# Altium schematic sheet [8]Sensing_SDC.SchDoc([8]Sensing_SDC)
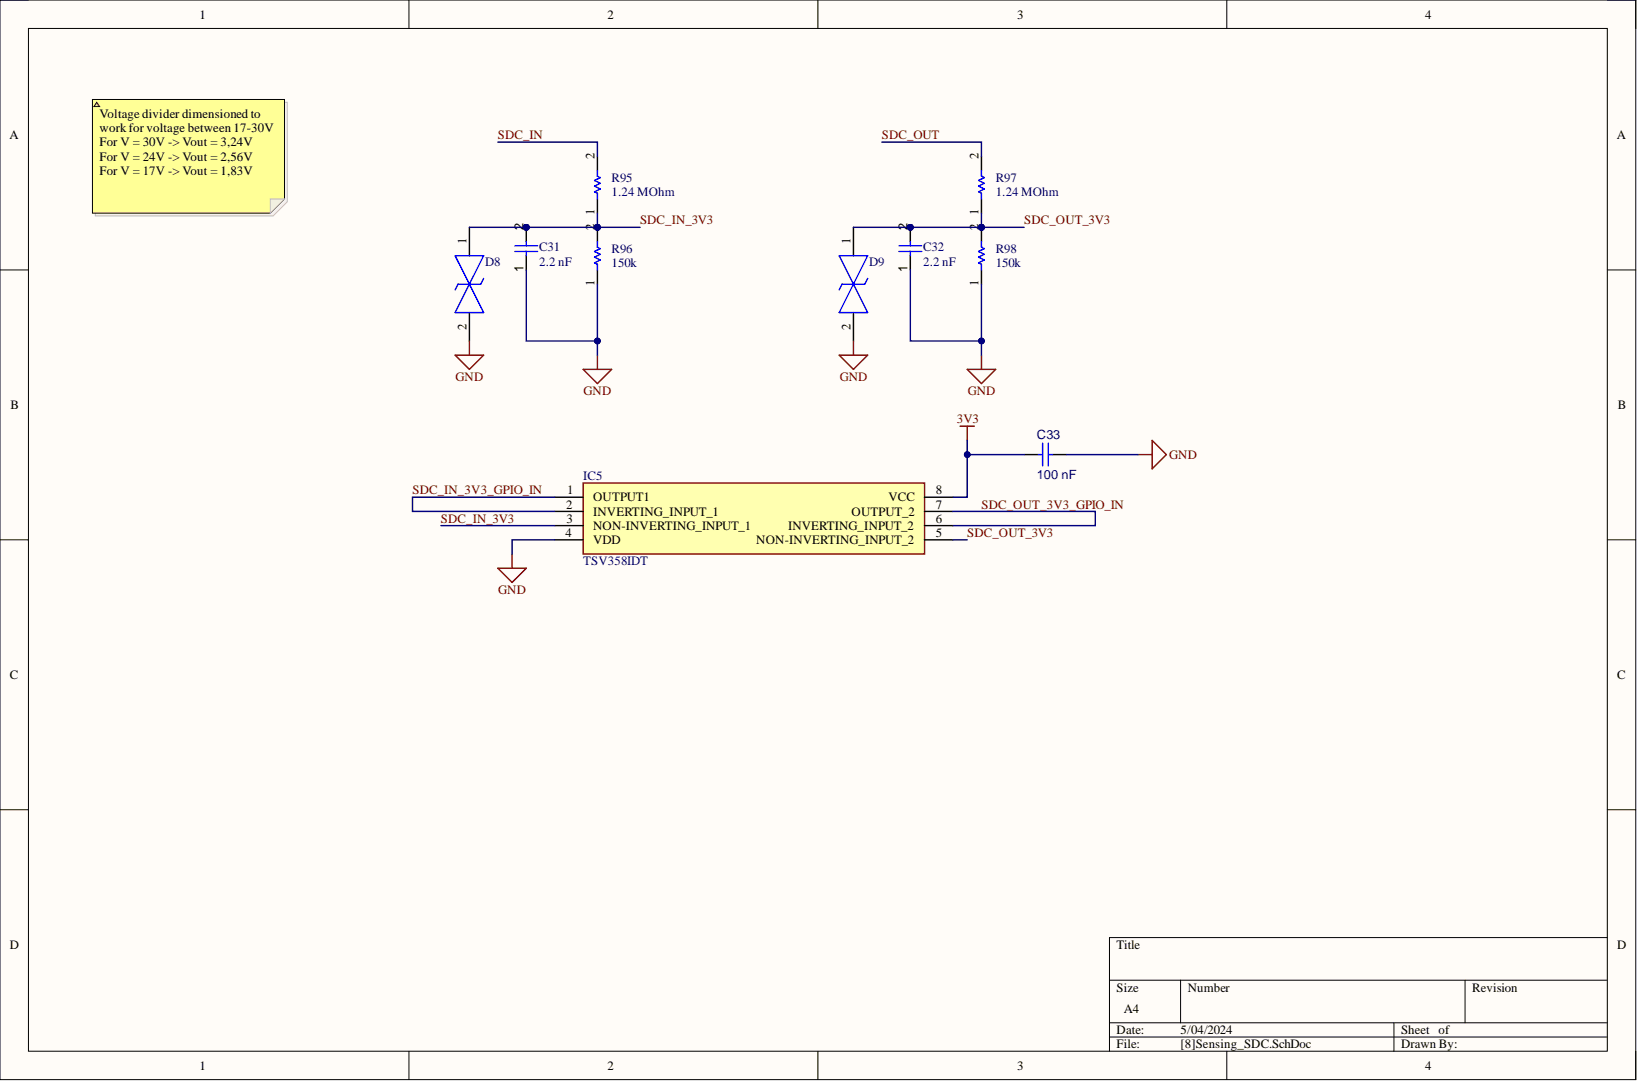
[diagram p0: [8]Sensing_SDC.SchDoc]

## Parts
C31  2.2 nF
C32  2.2 nF
C33  100 nF
D8  SMAJ30CA-TR  (value not drawn)
D9  SMAJ30CA-TR  (value not drawn)
IC5  TSV358IDT
R95  1.24 MOhm
R96  150k
R97  1.24 MOhm
R98  150k

## Nets
3V3: C33.2 IC5.8(VCC)
GND: C31.1 C32.1 C33.1 D8.2 D9.2 IC5.4(VDD) R96.1 R98.1
SDC_IN: R95.2
SDC_IN_3V3: C31.2 D8.1 IC5.3(NON-INVERTING_INPUT_1) R95.1 R96.2
SDC_IN_3V3_GPIO_IN: IC5.1(OUTPUT1) IC5.2(INVERTING_INPUT_1)
SDC_OUT: R97.2
SDC_OUT_3V3: C32.2 D9.1 IC5.5(NON-INVERTING_INPUT_2) R97.1 R98.2
SDC_OUT_3V3_GPIO_IN: IC5.6(INVERTING_INPUT_2) IC5.7(OUTPUT_2)

## Library data of the parts
C31 C32 = CMP-00009-1: 77-VJ0805Y222KXBAC; Component Kind: Standard; Library Name: EMEA- Squadra Corse PoliTO-  Politecnico di Torino
C33 = CMP-001-00085-5: VJ0805Y104MXATW1BC; Tech: SMD; Component Kind: Standard; ComponentLink1Description: Website; Package: 0805; Library Name: EMEA- Squadra Corse PoliTO-  Politecnico di Torino
D8 D9 = CMP-004-000005-1: TVS Diode Bi-Directional SMAJ30CA-TR 64.3V; Manufacturer_Name: STMicroelectronics; Arrow Part Number: SMAJ30CA-TR; Arrow Price/Stock: https://www.arrow.com/en/products/smaj30ca-tr/stmicroelectronics?region=nac; Component Kind: Standard; Datasheet Link: https://www.st.com/resource/en/datasheet/smaj10ca.pdf; Design Item ID: SMAJ30CA-TR; Height: 2.65mm; Manufacturer_Part_Number: SMAJ30CA-TR; Mouser Part Number: 511-SMAJ30CA-TR; Mouser Price/Stock: https://www.mouser.co.uk/ProductDetail/STMicroelectronics/SMAJ30CA-TR?qs=0TJjK1waviDY0EkLaKH12g%3D%3D; Source: SamacSys.SchLib; Library Name: EMEA- Squadra Corse PoliTO-  Politecnico di Torino
IC5 = CMP-030-000058-1: Manufacturer_Name: STMicroelectronics; Arrow Part Number: TSV358IDT; Arrow Price/Stock: https://www.arrow.com/en/products/tsv358idt/stmicroelectronics; Component Kind: Standard; Datasheet Link: http://www.st.com/web/en/resource/technical/document/datasheet/CD00063292.pdf; Description: TSV358IDT; Design Item ID: TSV358IDT; Height: 1.75mm; Manufacturer_Part_Number: TSV358IDT; Mouser Part Number: 511-TSV358IDT; Mouser Price/Stock: https://www.mouser.com/Search/Refine.aspx?Keyword=511-TSV358IDT; Source: SamacSys.SchLib; Library Name: EMEA- Squadra Corse PoliTO-  Politecnico di Torino
R95 R97 = CMP-009-00179-5: CRCW06031M24FKEA; Tech: SMD; Component Kind: Standard; ComponentLink1Description: Website; Package: 0603; Library Name: EMEA- Squadra Corse PoliTO-  Politecnico di Torino
R96 R98 = CMP-009-00179-5: MCT06030D1503BP100; Tech: SMD; Component Kind: Standard; ComponentLink1Description: Website; Package: 0603; Library Name: EMEA- Squadra Corse PoliTO-  Politecnico di Torino
